# Altium schematic sheet VCU_Schematic.SchDoc(VCU_Schematic)
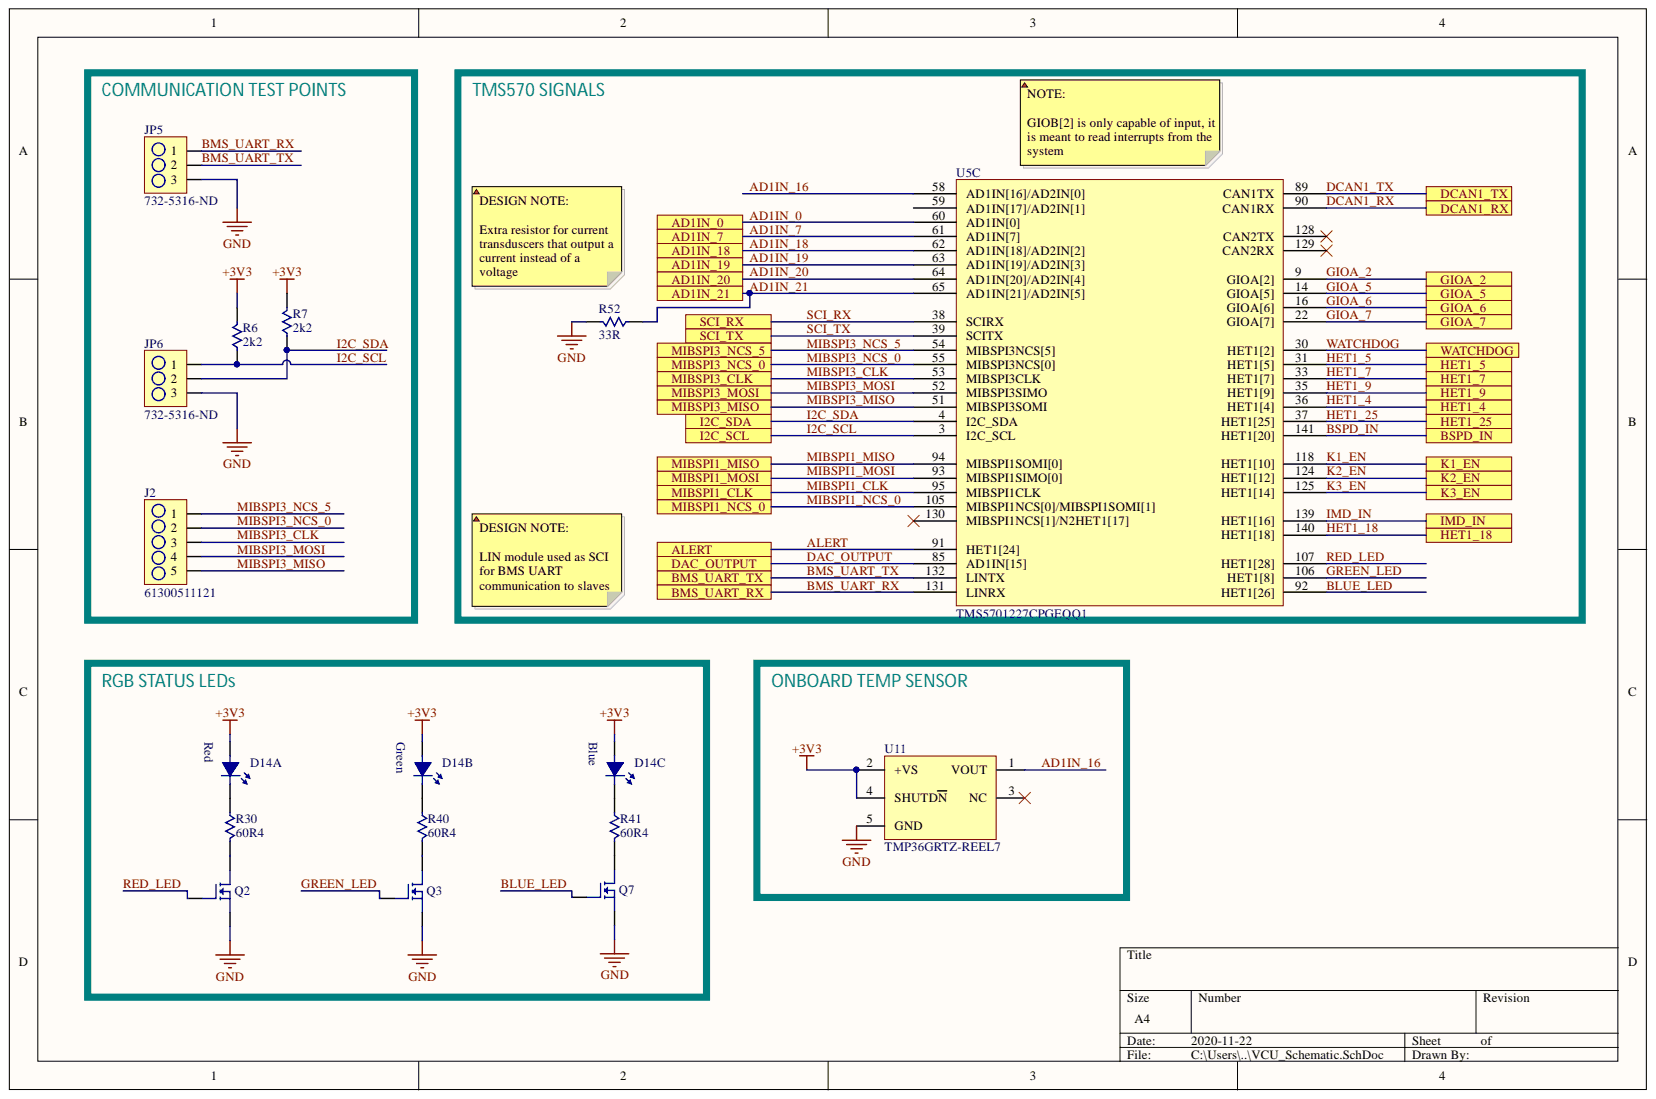
[diagram p0: VCU_Schematic.SchDoc]

## Parts
D14  EAST1616RGBB4  [LED_TRICOLOR]  (value not drawn)  (parts A,B,C)
J2  61300511121  [5PIN_HEADER]
JP5  732-5316-ND  [3PIN_HEADER]
JP6  732-5316-ND  [3PIN_HEADER]
Q2  BSS138LT3GOSCT-ND  [SOT-23-3]  (value not drawn)
Q3  BSS138LT3GOSCT-ND  [SOT-23-3]  (value not drawn)
Q7  BSS138LT3GOSCT-ND  [SOT-23-3]  (value not drawn)
R6  2k2  [R0402]
R7  2k2  [R0402]
R30  60R4  [R0402]
R40  60R4  [R0402]
R41  60R4  [R0402]
R52  33R  [R0805-3D]
U5  TMS5701227CPGEQQ1  [LQFP-144]  (part C)
U11  TMP36GRTZ-REEL7  [SOT-23-5]

## Ports
AD1IN_0, AD1IN_7, AD1IN_18, AD1IN_19, AD1IN_20, AD1IN_21, ALERT, BMS_UART_RX, BMS_UART_TX, BSPD_IN, DAC_OUTPUT, DCAN1_RX, DCAN1_TX, GIOA_2, GIOA_5, GIOA_6, GIOA_7, HET1_4, HET1_5, HET1_7, HET1_9, HET1_18, HET1_25, I2C_SCL, I2C_SDA, IMD_IN, K1_EN, K2_EN, K3_EN, MIBSPI1_CLK, MIBSPI1_MISO, MIBSPI1_MOSI, MIBSPI1_NCS_0, MIBSPI3_CLK, MIBSPI3_MISO, MIBSPI3_MOSI, MIBSPI3_NCS_0, MIBSPI3_NCS_5, SCI_RX, SCI_TX, WATCHDOG

## Nets
+3V3: D14.4 R6.2 R7.2 U11.2(+VS) U11.4(SHUTDN)
GND: JP5.3 JP6.3 Q2.3 Q3.3 Q7.3 R52.2 U11.5(GND)
AD1IN_0: U5.60(AD1IN[0]) ; port AD1IN_0
AD1IN_7: U5.61(AD1IN[7]) ; port AD1IN_7
AD1IN_16: U5.58(AD1IN[16]/AD2IN[0]) U11.1(VOUT)
AD1IN_18: U5.62(AD1IN[18]/AD2IN[2]) ; port AD1IN_18
AD1IN_19: U5.63(AD1IN[19]/AD2IN[3]) ; port AD1IN_19
AD1IN_20: U5.64(AD1IN[20]/AD2IN[4]) ; port AD1IN_20
AD1IN_21: R52.1 U5.65(AD1IN[21]/AD2IN[5]) ; port AD1IN_21
ALERT: U5.91(HET1[24]) ; port ALERT
BLUE_LED: Q7.1 U5.92(HET1[26])
BMS_UART_RX: JP5.1 U5.131(LINRX) ; port BMS_UART_RX
BMS_UART_TX: JP5.2 U5.132(LINTX) ; port BMS_UART_TX
BSPD_IN: U5.141(HET1[20]) ; port BSPD_IN
DAC_OUTPUT: U5.85(AD1IN[15]) ; port DAC_OUTPUT
DCAN1_RX: U5.90(CAN1RX) ; port DCAN1_RX
DCAN1_TX: U5.89(CAN1TX) ; port DCAN1_TX
GIOA_2: U5.9(GIOA[2]) ; port GIOA_2
GIOA_5: U5.14(GIOA[5]) ; port GIOA_5
GIOA_6: U5.16(GIOA[6]) ; port GIOA_6
GIOA_7: U5.22(GIOA[7]) ; port GIOA_7
GREEN_LED: Q3.1 U5.106(HET1[8])
HET1_4: U5.36(HET1[4]) ; port HET1_4
HET1_5: U5.31(HET1[5]) ; port HET1_5
HET1_7: U5.33(HET1[7]) ; port HET1_7
HET1_9: U5.35(HET1[9]) ; port HET1_9
HET1_18: U5.140(HET1[18]) ; port HET1_18
HET1_25: U5.37(HET1[25]) ; port HET1_25
I2C_SCL: JP6.1 R6.1 U5.3(I2C_SCL) ; port I2C_SCL
I2C_SDA: JP6.2 R7.1 U5.4(I2C_SDA) ; port I2C_SDA
IMD_IN: U5.139(HET1[16]) ; port IMD_IN
K1_EN: U5.118(HET1[10]) ; port K1_EN
K2_EN: U5.124(HET1[12]) ; port K2_EN
K3_EN: U5.125(HET1[14]) ; port K3_EN
MIBSPI1_CLK: U5.95(MIBSPI1CLK) ; port MIBSPI1_CLK
MIBSPI1_MISO: U5.94(MIBSPI1SOMI[0]) ; port MIBSPI1_MISO
MIBSPI1_MOSI: U5.93(MIBSPI1SIMO[0]) ; port MIBSPI1_MOSI
MIBSPI1_NCS_0: U5.105(MIBSPI1NCS[0]/MIBSPI1SOMI[1]) ; port MIBSPI1_NCS_0
MIBSPI3_CLK: J2.3 U5.53(MIBSPI3CLK) ; port MIBSPI3_CLK
MIBSPI3_MISO: J2.5 U5.51(MIBSPI3SOMI) ; port MIBSPI3_MISO
MIBSPI3_MOSI: J2.4 U5.52(MIBSPI3SIMO) ; port MIBSPI3_MOSI
MIBSPI3_NCS_0: J2.2 U5.55(MIBSPI3NCS[0]) ; port MIBSPI3_NCS_0
MIBSPI3_NCS_5: J2.1 U5.54(MIBSPI3NCS[5]) ; port MIBSPI3_NCS_5
RED_LED: Q2.1 U5.107(HET1[28])
SCI_RX: U5.38(SCIRX) ; port SCI_RX
SCI_TX: U5.39(SCITX) ; port SCI_TX
WATCHDOG: U5.30(HET1[2]) ; port WATCHDOG
NetD14_1: D14.1 R30.1
NetD14_2: D14.2 R40.1
NetD14_3: D14.3 R41.1
NetQ2_2: Q2.2 R30.2
NetQ3_2: Q3.2 R40.2
NetQ7_2: Q7.2 R41.2

Not connected: U5 59(AD1IN[17]/AD2IN[1]) 128(CAN2TX) 129(CAN2RX) 130(MIBSPI1NCS[1]/N2HET1[17]); U11 3(NC)

## Library data of the parts
D14 = EAST1616RGBB4: LED TRICOLOR RED GREEN BLUE; Manufacturer: Everlight; Supplier Part Number 1: 1080-1552-2-ND; Supplier 1: Digi-Key; Stock 1: 0; Manufacturer Part Number: EAST1616RGBB4; Component Kind: Standard; Library Name: PHANTOM_LIBRARY.SchLib
J2 = 61300511121: CONN_HeaderPins5pos WURTH ELEKTRONIK         61300511121            Board-To-Board Connector, Vertical, WR-PHD Series, Through Hole, Header, 5 Contacts, 2.54 mm; Manufacturer: Wurth Electronics; Pitch: 0.1inch; Number of Positions: 5; Gender: Male; Connector Type: Male Pin; Termination: Solder; Supplier Part Number 1: 732-5318-ND; Supplier 1: Digi-Key; Stock 1: 20896; REACH SVHC: Unknown; Pricing 1: 1=0.25, 10=0.209, 25=0.174, 50=0.145, 100=0.132, 1000=0.12 (USD); Packaging: Bulk; Number of Rows: 1; Number of Contacts: 5; Mount: Through Hole; Manufacturer Part Number: 61300511121; Lead Free: Lead Free; Contact Plating: Gold; Contact Mating Length: 0.236inch; Contact Material: Copper; Component Kind: Standard; Color: Black; Library Name: PHANTOM_LIBRARY.SchLib
JP5 JP6 = 61300311121: CONN_HeaderPins3pos WURTH ELEKTRONIK Board-To-Board Connector, Vertical, WR-PHD Series, Through Hole, Header, 3 Contacts, 2.54 mm; Manufacturer: Wurth Electronics; Pitch: 0.1inch; Number of Positions: 3; Gender: Male; Connector Type: Male Pin; Termination: Solder; Supplier Part Number 1: 732-5316-ND; Supplier 1: Digi-Key; Stock 1: 57026; REACH SVHC: Unknown; Pricing 1: 1=0.13, 10=0.106, 25=0.088, 50=0.074, 100=0.067, 1000=0.061 (USD); Packaging: Bulk; Number of Rows: 1; Number of Contacts: 3; Mount: Through Hole; Manufacturer Part Number: 61300311121; Lead Free: Lead Free; Contact Plating: Gold; Contact Mating Length: 0.236inch; Contact Material: Copper; ComponentLink1URL: http://www.farnell.com/cad/1737268.pdf; ComponentLink1Description: http://www.farnell.com/cad/1737268.pdf; Component Kind: Standard; Colour: Black; Case/Package: 100; Library Name: PHANTOM_LIBRARY.SchLib
Q2 Q3 Q7 = N-FET-50V-0.2A: MOSFET N-CH 50V 200MA SOT-23; Manufacturer: ON Semiconductor; Turn-On Delay Time: 20ns; Threshold Voltage: 500mV; Rds On Max: 3.5R; Power Dissipation: 225mW; Number of Elements: 1; Input Capacitance: 50pF; Gate to Source Voltage (Vgs): 20V; Element Configuration: Single; Width: 1.3mm; Voltage Rating (DC): 50V; Turn-Off Delay Time: 20ns; Supplier Part Number 1: BSS138LT3GOSDKR-ND; Supplier 1: Digi-Key; Stock 1: 141691; REACH SVHC: No SVHC; Radiation Hardening: No; Pricing 1: 1=0.25, 10=0.205, 25=0.172, 100=0.084, 250=0.08272, 500=0.0701, 1000=0.04871, 2500=0.04527, 5000=0.03916 (USD); Packaging: Tape and Reel; Package Quantity: 1; On-State Resistance: 3.5R; Number of Pins: 3; Nominal Vgs: 500mV; Min Operating Temperature: -55°C; Max Power Dissipation: 225mW; Max Operating Temperature: 150°C; Manufacturer Part Number: BSS138LT3G; Library Name: Transistors.SchLib; Length: 2.9mm; Lead Free: Lead Free; Height: 0.94mm; Halogen Free: Halogen Free; Drain to Source Voltage (Vdss): 50V; Drain to Source Resistance: 3.5R; Drain to Source Breakdown Voltage: 50V; Current Rating: 200mA; Continuous Drain Current (ID): 200mA; ComponentLink5URL: http://www.onsemi.com/pub_link/Collateral/BSS138LT1-D.PDF?src-supplier=Future+Electronics; ComponentLink5Description: http://www.onsemi.com/pub_link/Collateral/BSS138LT1-D.PDF?src-supplier=Future+Electronics; ComponentLink4URL: https://www.verical.com/server-webapp/html/getDatasheet?partID=141721&src-supplier=Verical; ComponentLink4Description: https://www.verical.com/server-webapp/html/getDatasheet?partID=141721&src-supplier=Verical; ComponentLink3URL: http://www.onsemi.com/pub_link/Collateral/BSS138LT1-D.PDF?src-supplier=Avnet; ComponentLink3Description: http://www.onsemi.com/pub_link/Collateral/BSS138LT1-D.PDF?src-supplier=Avnet; ComponentLink2URL: http://www.farnell.com/datasheets/2353875.pdf?src-supplier=Element14; ComponentLink2Description: http://www.farnell.com/datasheets/2353875.pdf?src-supplier=Element14; ComponentLink1URL: http://www.onsemi.com/pub/Collateral/BSS138LT1-D.PDF?src-supplier=Digi-Key; ComponentLink1Description: http://www.onsemi.com/pub/Collateral/BSS138LT1-D.PDF?src-supplier=Digi-Key; Component Kind: Standard; Case/Package: SOT-23-3
R6 R7 = RC0402JR-072K2L: RES SMD 2.2K OHM 5% 1/16W 0402; Manufacturer: Yageo; Working Voltage: 50V; Temperature Coefficient: 100ppm/°C; Resistance: 2.2kR; Power Rating: 63mW; Width: 0.02inch; Weight: 2.3E-05oz; Voltage Rating: 50V; Tolerance: 5%; Termination: SMD/SMT; Supplier Part Number 1: 311-2.2KJRCT-ND; Supplier 1: Digi-Key; Stock 1: 7890813; REACH SVHC: Unknown; Pricing 1: 1=0.1, 10=0.018, 100=0.0073, 1000=0.0033, 2500=0.0029, 5000=0.0024 (USD); Packaging: Tape and Reel; Package Quantity: 1; Number of Terminations: 2; Min Operating Temperature: -55°C; Military Standard: Not; Max Power Dissipation: 63mW; Max Operating Temperature: 155°C; Manufacturer Part Number: RC0402JR-072K2L; Length: 1mm; Lead Free: Lead Free; Height: 0.016inch; Features: Moisture Resistant; Depth: 0.5mm; Composition: Thick Film; Component Kind: Standard; Case Code (Metric): 1005; Case Code (Imperial): 0402; Case/Package: 0402; Library Name: PHANTOM_LIBRARY.SchLib
R30 R40 R41 = RMCF0402FT60R4: RES SMD 60.4 OHM 1% 0402 1/16W 100ppm/C Molded SMD SMD Paper T/R; Manufacturer: Stackpole Electronics; Temperature Coefficient: 100ppm/°C; Resistance: 60.4R; Max Power Dissipation: 63mW; Width: 0.02inch; Tolerance: 1%; Supplier Part Number 1: RMCF0402FT60R4CT-ND; Supplier 1: Digi-Key; Stock 1: 29830; Radiation Hardening: No; Pricing 1: 1=0.1, 10=0.016, 100=0.0064, 1000=0.0029, 2500=0.0025, 5000=0.0021 (USD); Packaging: Tape and Reel; Number of Terminations: 2; Mount: Surface Mount; Min Operating Temperature: -55°C; Max Operating Temperature: 155°C; Manufacturer Part Number: RMCF0402FT60R4; Length: 0.039inch; Lead Free: Lead Free; Height: 0.016inch; Features: Automotive AEC-Q200; Contact Plating: Matte,Tin; Composition: Thick Film; Component Kind: Standard; Case Code (Metric): 1005; Case Code (Imperial): 0402; Case/Package: 0402; Library Name: PHANTOM_LIBRARY.SchLib
R52 = ESR10EZPJ330: RES SMD 33 OHM 5% 0.4W 0805; Manufacturer: Rohm; Temperature Coefficient: 200ppm/°C; Power Rating: 400mW; Width: 0.049inch; Tolerance: 5%; Supplier Part Number 1: RHM33KCT-ND; Supplier 1: Digi-Key; Stock 1: 8927; Resistance: 33R; Pricing 1: 1=0.1, 10=0.083, 100=0.0318, 1000=0.014, 2500=0.0121 (USD); Packaging: Tape and Reel; Package Quantity: 1; Number of Terminations: 2; Min Operating Temperature: -55°C; Max Power Dissipation: 400mW; Max Operating Temperature: 155°C; Manufacturer Part Number: ESR10EZPJ330; Length: 0.079inch; Lead Free: Lead Free; Height: 0.026inch; Features: Automotive AEC-Q200; Composition: Thick Film; ComponentLink3URL: http://www1.futureelectronics.com/doc/ROHM%20SEMICONDUCTOR/ESR10EZPD.pdf?src-supplier=Future+Electronics; ComponentLink3Description: http://www1.futureelectronics.com/doc/ROHM%20SEMICONDUCTOR/ESR10EZPD.pdf?src-supplier=Future+Electronics; ComponentLink2URL: https://www.rohm.com/datasheet/ESR01MZPF/esr-e?src-supplier=Digi-Key; ComponentLink2Description: https://www.rohm.com/datasheet/ESR01MZPF/esr-e?src-supplier=Digi-Key; ComponentLink1URL: http://www.rohm.com/web/global/datasheet/ESR01MZPF/esr-e?src-supplier=Digi-Key; ComponentLink1Description: http://www.rohm.com/web/global/datasheet/ESR01MZPF/esr-e?src-supplier=Digi-Key; Component Kind: Standard; Case Code (Metric): 2012; Case Code (Imperial): 0805; Case/Package: 0805; Library Name: PHANTOM_LIBRARY.SchLib
U5 = TMS5701227CPGEQQ1: IC MCU 16-Bit/32-Bit Hercules TMS570 ARM Cortex R4F RISC 1280KB Flash 1.2V/3.3V 144-Pin LQFP; Manufacturer: Texas Instruments; Watchdog Timer: Yes; RAM Size: 192KB; Peripherals: DMA,POR,PWM,WDT; Oscillator Type: External; Number of Timers/Counters: 2; Number of Programmable I/O: 58; Memory Size: 1.25MB; Max Frequency: 160MHz; Interface: CAN,EBI/EMI,Ethernet,I2C,LIN,SCI,SPI,UART,USART; Density: 1310720B; Supplier Part Number 1: 296-42451-ND; Supplier 1: Digi-Key; Stock 1: 2872; Pricing 1: 1=24.99, 10=23.046, 60=22.0098, 120=19.6794, 540=17.8669 (USD); Packaging: Tray; Number of Pins: 144; Number of I/Os: 58; Number of GPIO: 58; Min Operating Temperature: -40°C; Memory Type: FLASH; Max Operating Temperature: 125°C; Manufacturer Part Number: TMS5701227CPGEQQ1; Lead Free: Lead Free; Frequency: 160MHz; Core Architecture: ARM; Component Kind: Standard; Case/Package: LQFP; Library Name: PHANTOM_LIBRARY.SchLib
U11 = TMP36GRTZ-REEL7: IC TEMP SENSOR ANALOG -40C TO 125C ANALOG DEVICES   TMP36GRTZ-REEL7   TEMPERATURE SENSOR, 3C, 5-SOT-23; Output Current: 50uA; Min Supply Voltage: 2.7V; Max Supply Voltage: 5.5V; Width: 1.7mm; Supplier Part Number 1: TMP36GRTZ-REEL7CT-ND; Supplier 1: Digi-Key; Stock 1: 5163; REACH SVHC: No SVHC; Radiation Hardening: No; Pricing 1: 1=1.59, 10=1.431, 25=1.35, 100=1.1502, 500=0.945, 1000=0.783 (USD); Packaging: Tape and Reel; Package Quantity: 3000; Output Type: Analog; Operating Supply Current: 50uA; Number of Pins: 5; Nominal Supply Current: 50uA; Min Operating Temperature: -40°C; Max Operating Temperature: 125°C; Manufacturer Part Number: TMP36GRTZ-REEL7; Manufacturer: Analog Devices; Library Name: ICs.SchLib; Length: 3mm; Lead Free: Contains Lead; Interface: Analog; Height: 1.3mm; ComponentLink7URL: http://www.mouser.com/ds/2/609/TMP35_36_37-794389.pdf?src-supplier=Mouser; ComponentLink7Description: http://www.mouser.com/ds/2/609/TMP35_36_37-794389.pdf?src-supplier=Mouser; ComponentLink6URL: http://www.farnell.com/datasheets/1793789.pdf?src-supplier=Element14; ComponentLink6Description: http://www.farnell.com/datasheets/1793789.pdf?src-supplier=Element14; ComponentLink5URL: https://www.verical.com/server-webapp/html/getDatasheet?partID=86485&src-supplier=Verical; ComponentLink5Description: https://www.verical.com/server-webapp/html/getDatasheet?partID=86485&src-supplier=Verical; ComponentLink4URL: http://www.analog.com/static/imported-files/data_sheets/TMP35_36_37.pdf?src-supplier=Avnet; ComponentLink4Description: http://www.analog.com/static/imported-files/data_sheets/TMP35_36_37.pdf?src-supplier=Avnet; ComponentLink3URL: http://www.analog.com/static/imported-files/data_sheets/TMP35_36_37.pdf?src-supplier=Avnet+Europe; ComponentLink3Description: http://www.analog.com/static/imported-files/data_sheets/TMP35_36_37.pdf?src-supplier=Avnet+Europe; ComponentLink2URL: https://www.analog.com/media/en/technical-documentation/data-sheets/TMP35_36_37.pdf?src-supplier=Digi-Key; ComponentLink2Description: https://www.analog.com/media/en/technical-documentation/data-sheets/TMP35_36_37.pdf?src-supplier=Digi-Key; ComponentLink1URL: http://www.farnell.com/datasheets/1943729.pdf?src-supplier=Element14; ComponentLink1Description: http://www.farnell.com/datasheets/1943729.pdf?src-supplier=Element14; Component Kind: Standard; Case/Package: SOT-23
